# Altium schematic sheet ThermoFlagger.SchDoc(ThermoFlagger)
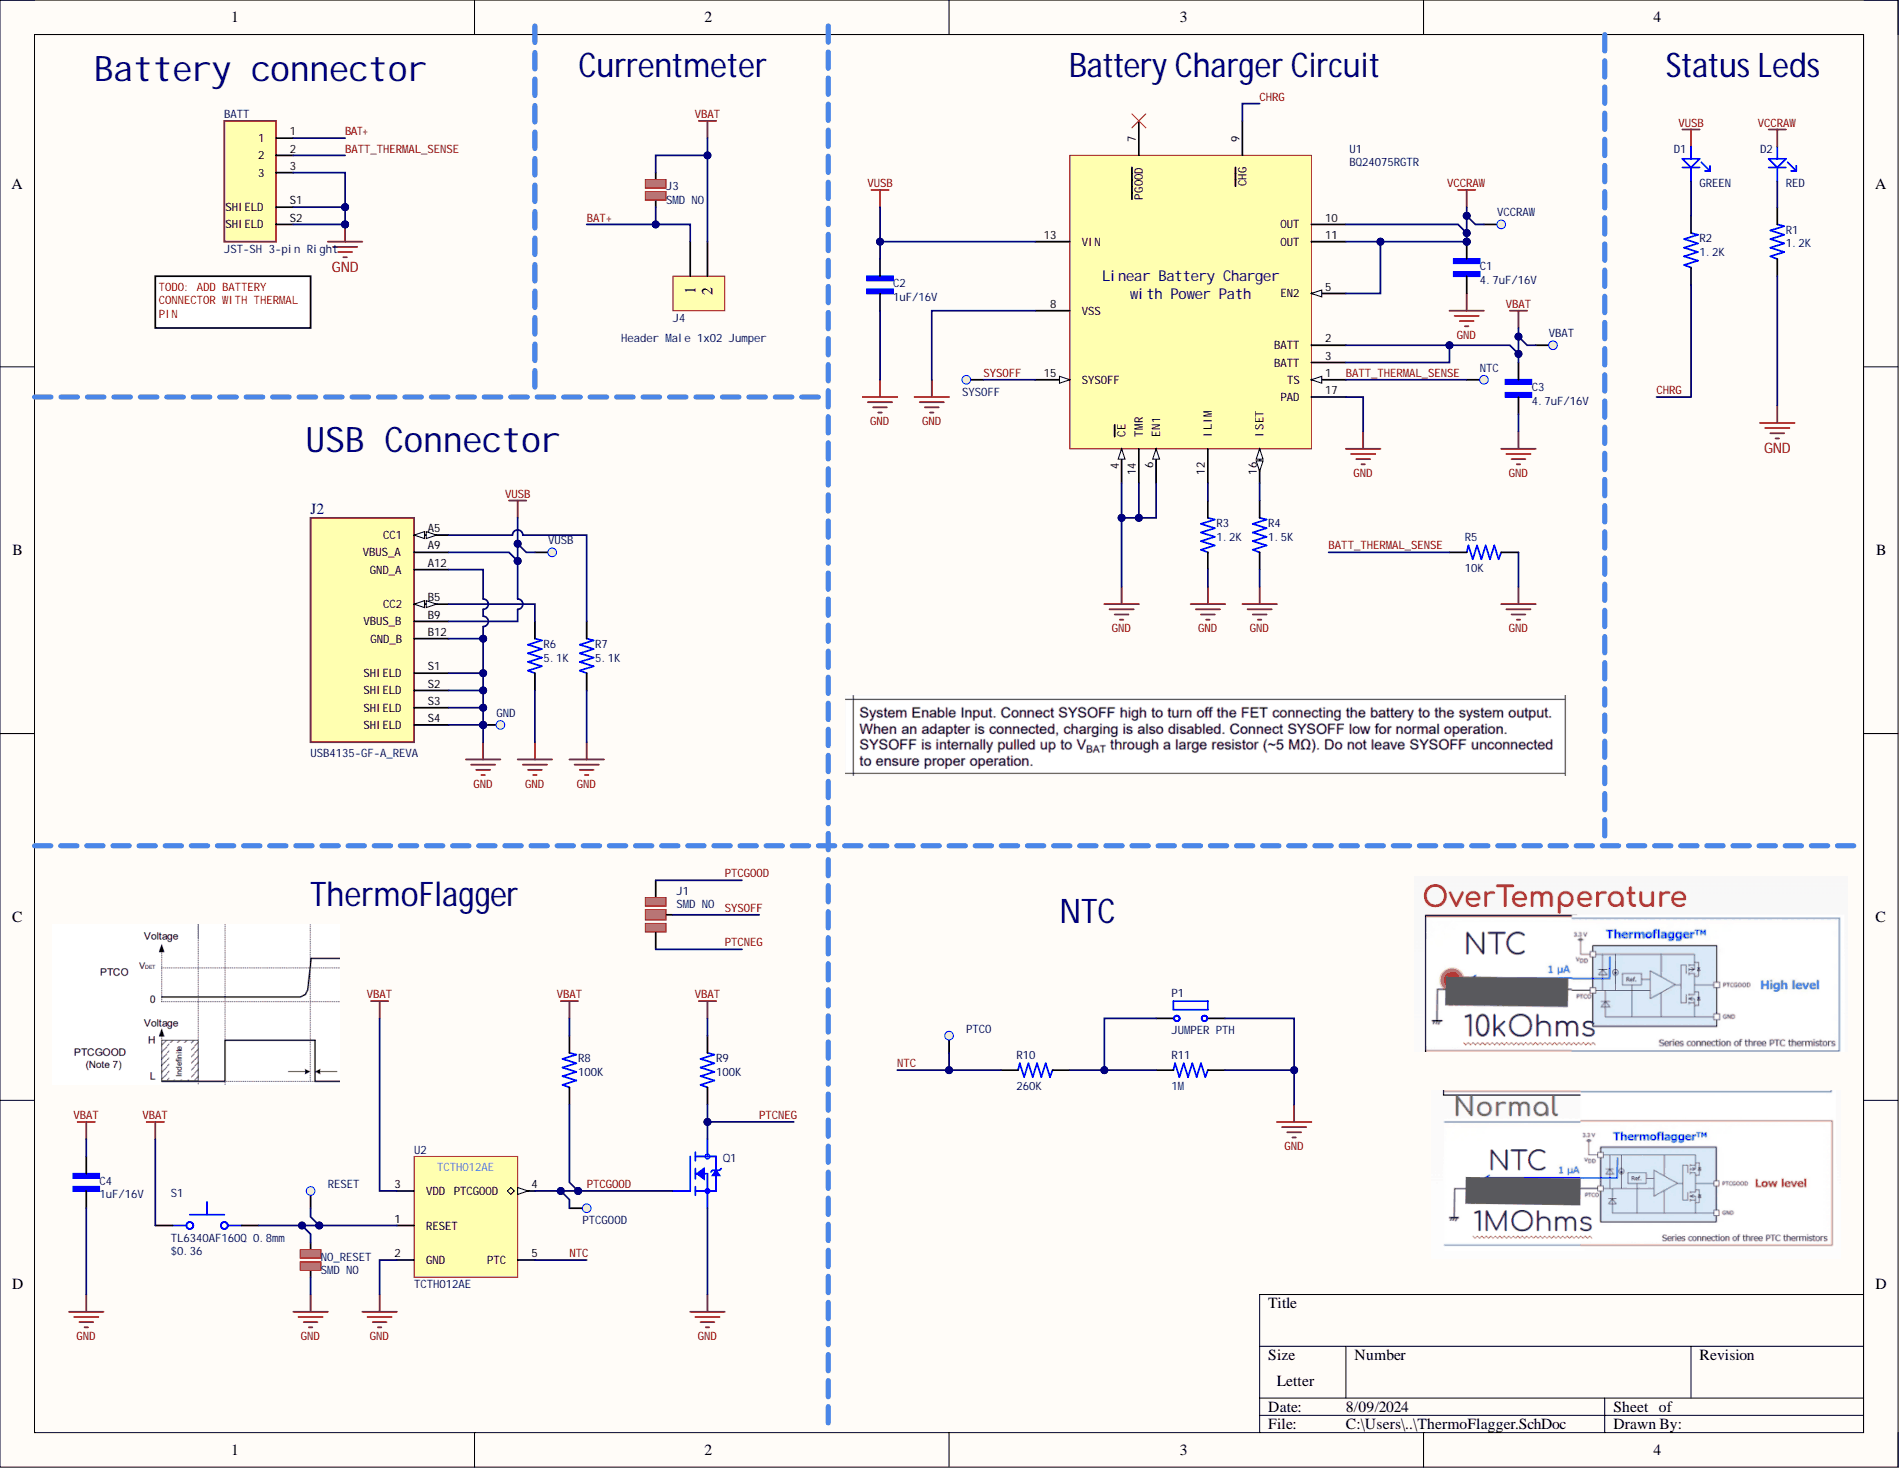
[diagram p0: ThermoFlagger.SchDoc]

## Parts
BATT  JST-SH 3-pin Right  [JST_BM03B-SRSS-RightAngle]
C1  4.7uF/16V  [C0603]
C2  1uF/16V  [C0603]
C3  4.7uF/16V  [C0603]
C4  1uF/16V  [C0603]
D1  GREEN  [LED 0603]
D2  RED  [LED 0603]
GND  Test point 1.2mm  [TEST_POINT_1.2MM]  (value not drawn)  (no BOM)
J1  SMD NO  [JUMPER_SMD_NO_2WAY]
J2  USB4135-GF-A_REVA  [GCT_USB4135 16-pin]
J3  SMD NO  [JUMPER_SMD_NO]
J4  Header Male 1x02 Jumper  [Header 1x02 Jumper]
NO_RESET  SMD NO  [JUMPER_SMD_NO]
NTC  Test point 1.2mm  [TEST_POINT_1.2MM]  (value not drawn)  (no BOM)
P1  JUMPER PTH  [HDR1X2]  (no BOM)
PTCGOOD  Test point 1.2mm  [TEST_POINT_1.2MM]  (value not drawn)  (no BOM)
PTCO  Test point 1.2mm  [TEST_POINT_1.2MM]  (value not drawn)  (no BOM)
Q1  0.19A/1.1V  [SOT-23-3]  (value not drawn)
R1  1.2K  [R0603]
R2  1.2K  [R0603]
R3  1.2K  [R0603]
R4  1.5K  [R0603]
R5  10K  [R0603]
R6  5.1K  [R0603]
R7  5.1K  [R0603]
R8  100K  [R0603]
R9  100K  [R0603]
R10  260K  [R0603]
R11  1M  [R0603]
RESET  Test point 1.2mm  [TEST_POINT_1.2MM]  (value not drawn)  (no BOM)
S1  TL6340AF160Q 0.8mm  Parameter 1: $0.36  [TL6340AF160Q]
SYSOFF  Test point 1.2mm  [TEST_POINT_1.2MM]  (value not drawn)  (no BOM)
U1  BQ24075RGTR  [VQFN16]
U2  TCTH012AE  [SOT-553 (ESV)]
VBAT  Test point 1.2mm  [TEST_POINT_1.2MM]  (value not drawn)  (no BOM)
VCCRAW  Test point 1.2mm  [TEST_POINT_1.2MM]  (value not drawn)  (no BOM)
VUSB  Test point 1.2mm  [TEST_POINT_1.2MM]  (value not drawn)  (no BOM)

## Nets
GND: BATT.3 BATT.S1(SHIELD) BATT.S2(SHIELD) C1.2 C2.2 C3.2 C4.2 GND.1 J2.A12(GND_A) J2.B12(GND_B) J2.S1(SHIELD) J2.S2(SHIELD) J2.S3(SHIELD) J2.S4(SHIELD) NO_RESET.1 P1.2 Q1.2 R1.1 R3.1 R4.1 R5.1 R6.1 R7.1 R11.1 U1.4(CE) U1.6(EN1) U1.8(VSS) U1.14(TMR) U1.17(PAD) U2.2(GND)
VBAT: C3.1 C4.1 J3.1 J4.2 R8.2 R9.2 S1.1 U1.2(BATT) U1.3(BATT) U2.3(VDD) VBAT.1
VCCRAW: C1.1 D2.1 U1.5(EN2) U1.10(OUT) U1.11(OUT) VCCRAW.1
VUSB: C2.1 D1.1 J2.A9(VBUS_A) J2.B9(VBUS_B) U1.13(VIN) VUSB.1
BAT+: BATT.1 J3.2 J4.1
BATT_THERMAL_SENSE: BATT.2 NTC.1 R5.2 U1.1(TS)
CHRG: R2.1 U1.9(CHG)
NTC: PTCO.1 R10.2 U2.5(PTC)
PTCGOOD: J1.3 PTCGOOD.1 Q1.1 R8.1 U2.4(PTCGOOD)
PTCNEG: J1.1 Q1.3 R9.1
SYSOFF: J1.2 SYSOFF.1 U1.15(SYSOFF)
NetD1_2: D1.2 R2.2
NetD2_2: D2.2 R1.2
NetJ2_A5: J2.A5(CC1) R7.2
NetJ2_B5: J2.B5(CC2) R6.2
NetNO_RESET_2: NO_RESET.2 RESET.1 S1.2 U2.1(RESET)
NetP1_1: P1.1 R10.1 R11.2
NetR3_2: R3.2 U1.12(ILIM)
NetR4_2: R4.2 U1.16(ISET)

Not connected: U1 7(PGOOD)

## Library data of the parts
BATT = JST-SH 3-pin Right: Connector Header, SR Series, 3 Position, 1.00mm, 50V; Manufacturer: JST; Manufacturer Part Number: BM03B-SRSS-TB(LF)(SN); Contact Resistance: 20mR; Current Rating: 1A; Gender: Male; Insulation Resistance: 100MR; Mated Stacking Heights: 4.9mm; Number of Contacts: 3; Category: Connectors; Color: Natural; Component Kind: Standard; Connector Type: Header; Contact Finish - Mating: TIN; Contact Material: Copper Alloy; Contact Plating: Tin,Lead; Contact Shape: Square; Contact Type: Male Pin; Fastening Type: Pull; Flammability Rating: UL94 V-0; Housing Color: Natural; Housing Material: Nylon; Insulation Color: Natural; Insulation Material: Nylon; Lead Free: Lead Free; Lead Pitch: 1mm; Library Name: Connectors.SchLib; Material: Polyamide; Max Operating Temperature: 85°C; Min Operating Temperature: -25°C; Mount: Surface Mount; Number of Positions: 3; Number of Rows: 1; Packaging: Cut Tape; Pricing 1: 5=0.1844, 50=0.1492, 150=0.1342, 1500=0.1078, 3000=0.0997, 4500=0.0948 (USD); Reach SVHC Compliant: No; RoHS: Yes; Series: SR; Stock 1: 136525; Supplier 1: LCSC; Supplier 2: Digi-Key; Supplier Part Number 1: C160389; Supplier Part Number 2: 455-1789-2-ND; Termination: Solder; Voltage Rating: 50V; Voltage Rating (AC): 50V
C1 C3 = C0603 4.7uF/16V: 0603 4.7 uF 16 V ±10% Tolerance X5R Multilayer Ceramic Capacitor; Manufacturer: Murata; Manufacturer Part Number: GRT188R61C475KE13D; Value: 4.7uF; Voltage Rating: 16V; Case Code (Imperial): 0603; Case Code (Metric): 1608; Case/Package: 0603; Category: Ceramic Capacitors; Component Kind: Standard; Length: 0.063inch; Library Name: Capacitors.SchLib; Max Operating Temperature: 85°C; Min Operating Temperature: -55°C; Mounting Technology: Surface Mount; Packaging: Cut Tape; Pricing 1: 1=0.16, 10=0.066, 50=0.066, 100=0.038, 1000=0.034, 10000=0.029 (USD); Ratings: AEC-Q200; RoHS: Yes; RoHS Compliant: Yes; Series: GRT; Stock 1: 39950; Supplier 1: Mouser; Supplier Part Number 1: 81-GRT188R61C475KE3D; Thickness: 0.035inch; Tolerance: 10%; Width: 0.031inch
C2 C4 = C0603 1uF/16V X5R: MLCC 1uF 16V X5R 10% SMD 0603 85C Paper T/R; Manufacturer: Yageo; Manufacturer Part Number: CC0603KRX5R7BB105; Dissipation Factor: 7%; Capacitance: 1uF; Case Code (Imperial): 0603; Case Code (Metric): 1608; Case/Package: 0603; Category: Ceramic Capacitors; Component Kind: Standard; Construction: Flat; Depth: 0.8mm; Dielectric: X5R; Halogen Free: Halogen Free; Height: 0.8mm; Lead Free: Lead Free; Length: 1.6mm; Library Name: Capacitors.SchLib; Max Operating Temperature: 85°C; Min Operating Temperature: -55°C; Mount: Surface Mount; Number of Pins: 2; Package Quantity: 4000; Packaging: Tape and Reel; Pricing 1: 1=0.17000, 10=0.09100, 50=0.09100, 100=0.04100, 1000=0.03400, 10000=0.02100 (USD); Radiation Hardening: No; REACH SVHC: Unknown; Resistor Type: Ceramic; RoHS: Yes; RoHS Compliant: Yes; Series: CC; Stock 1: 726921; Supplier 1: Mouser; Supplier Part Number 1: 603-CC603KRX5R7BB105; Termination: SMD/SMT; Thickness: 0.035inch; Tolerance: 10%; Voltage Rating: 16V; Voltage Rating (DC): 16V; Width: 0.8mm
D1 = LED 0603 GREEN: Green 575nm LED Indication - Discrete 2V 0603; Manufacturer: Wurth Electronics; Manufacturer Part Number: 150060VS55040; Dominant Wavelength: 573nm; Lens Size: 0.8mm; Lens Style: Flat; Lens Transparency: Diffused; Luminous Intensity: 60mcd; Test Current: 20mA; Viewing Angle: 140°; Case Code (Imperial): 0603; Case Code (Metric): 1608; Case/Package: 0603; Category: LEDs; Color: Green; Component Kind: Standard; Forward Voltage: 2V; Height: 0.5mm; Length: 1.6mm; Library Name: LED.SchLib; Mount: Surface Mount; Packaging: Cut Tape; Pricing 1: 1=0,18000, 10=0,16200, 50=0,16200, 100=0,14700, 1000=0,11700, 10000=0,11700 (USD); RoHS: No; Stock 1: 24940; Supplier 1: Mouser; Supplier Part Number 1: 710-150060VS55040; Width: 0.8mm
D2 = LED 0603 RED: Red 625nm LED Indication - Discrete 2V 0603; Manufacturer: Wurth Electronics; Manufacturer Part Number: 150060RS55040; Dominant Wavelength: 624nm; Lens Size: 0.8mm; Lens Style: Flat; Lens Transparency: Diffused; Luminous Intensity: 90mcd; Test Current: 20mA; Viewing Angle: 140°; Case Code (Imperial): 0603; Case Code (Metric): 1608; Case/Package: 0603; Category: LEDs; Color: Red; Component Kind: Standard; Forward Voltage: 2V; Height: 0.5mm; Length: 1.6mm; Library Name: LED.SchLib; Mount: Surface Mount; Packaging: Tape and Reel; Pricing 1: 1=0.18000, 10=0.16200, 100=0.14700, 500=0.13200, 1000=0.11700, 2000=0.11700 (USD); RoHS: No; Stock 1: 8586; Supplier 1: Mouser; Supplier Part Number 1: 710-150060RS55040; Width: 0.8mm
GND NTC PTCGOOD PTCO RESET SYSOFF VBAT VCCRAW VUSB = Test point: SMD test point; Library Name: Connectors.SchLib
J1 = JUMPER_SMD_NO_2WAY: Component Kind: Net Tie; Library Name: Jumpers.SchLib
J2 = USB4135-GF-A_REVA: Usb C Rec Gf Ra 6P SMT & SMT She; Manufacturer 1: Global Connector Technology; Category: Connectors; Component Kind: Standard; Library Name: Connectors.SchLib; Manufacturer Part Number 1: USB4135-GF-A; Pricing 1: 1=0.67300, 50=0.59700, 100=0.56000, 250=0.52200, 500=0.46600, 1000=0.43300, 1500=0.40000, 3000=0.33600 (USD); RoHS: No; Stock 1: 1196; Supplier 1: Mouser; Supplier Part Number 1: 640-USB4135-GF-A
J3 NO_RESET = JUMPER_SMD_NO: Rule: Short-Circuit Constraint [Short Circuit - Allowed]; Component Kind: Net Tie; Library Name: Jumpers.SchLib
J4 = Header Male Jumper 1x02: Component Kind: Standard; Description: Header Male Jumper 1x02; Library Name: Connectors.SchLib
P1 = JUMPER PTH NO: Description: JUMPER PTH NO; Library Name: Jumpers.SchLib
Q1 = MOSFET N-CH NX7002AK,215: MOSFET Transistor, N Channel, 190 mA, 60 V, 3 ohm, 10 V, 1.6 V; Manufacturer: Nexperia; Category: MOSFETs; Component Kind: Standard; Library Name: DiscreteSemi.SchLib; Manufacturer Part Number: NX7002AK,215; Pricing 1: 1=0.18, 10=0.131, 50=0.131, 100=0.059, 1000=0.036, 10000=0.021 (USD); RoHS: Yes; RoHS Compliant: Yes; Stock 1: 451760; Supplier 1: Mouser; Supplier 2: LCSC; Supplier Part Number 1: 771-NX7002AK,215; Supplier Part Number 2: C255584
R1-R3 = R0603 1.2K: RES 0603 1.2K OHM 1% 1/10W; Manufacturer: Yageo; Manufacturer Part Number: RC0603FR-071K2L; Max Power Dissipation: 100mW; Resistance: 1.2kR; Temperature Coefficient: 100ppm/°C; Voltage Rating: 50V; Case Code (Imperial): 0603; Case Code (Metric): 1608; Case/Package: 0603; Category: Chip SMD Resistors; Component Kind: Standard; ComponentLink1Description: http://www.farnell.com/datasheets/443971.pdf?src-supplier=Farnell; ComponentLink1URL: http://www.farnell.com/datasheets/443971.pdf?src-supplier=Farnell; ComponentLink2Description: http://www.farnell.com/datasheets/1868787.pdf?src-supplier=Farnell; ComponentLink2URL: http://www.farnell.com/datasheets/1868787.pdf?src-supplier=Farnell; ComponentLink3Description: http://www.farnell.com/datasheets/2167052.pdf?src-supplier=Farnell; ComponentLink3URL: http://www.farnell.com/datasheets/2167052.pdf?src-supplier=Farnell; ComponentLink4Description: http://www.mouser.com/ds/2/447/RC0603-272842.pdf?src-supplier=Mouser; ComponentLink4URL: http://www.mouser.com/ds/2/447/RC0603-272842.pdf?src-supplier=Mouser; ComponentLink5Description: http://components.arrow.com/part/dataview/0323_00047720?src-supplier=Arrow+Europe; ComponentLink5URL: http://components.arrow.com/part/dataview/0323_00047720?src-supplier=Arrow+Europe; ComponentLink6Description: http://www.farnell.com/datasheets/443971.pdf?src-supplier=Element14; ComponentLink6URL: http://www.farnell.com/datasheets/443971.pdf?src-supplier=Element14; ComponentLink7Description: http://www.farnell.com/datasheets/2167052.pdf?src-supplier=Newark; ComponentLink7URL: http://www.farnell.com/datasheets/2167052.pdf?src-supplier=Newark; ComponentLink8Description: http://www.farnell.com/datasheets/1868787.pdf?src-supplier=Element14; ComponentLink8URL: http://www.farnell.com/datasheets/1868787.pdf?src-supplier=Element14; ComponentLink9Description: http://www.farnell.com/datasheets/2167052.pdf?src-supplier=Element14; ComponentLink9URL: http://www.farnell.com/datasheets/2167052.pdf?src-supplier=Element14; ComponentLink10Description: http://www.yageo.com/documents/recent/PYu-RC0603_51_RoHS_L_7.pdf?src-supplier=Future+Electronics; ComponentLink10URL: http://www.yageo.com/documents/recent/PYu-RC0603_51_RoHS_L_7.pdf?src-supplier=Future+Electronics; ComponentLink11Description: https://www.verical.com/server-webapp/html/getDatasheet?partID=326670&src-supplier=Verical; ComponentLink11URL: https://www.verical.com/server-webapp/html/getDatasheet?partID=326670&src-supplier=Verical; ComponentLink12Description: http://www.farnell.com/datasheets/1868787.pdf?src-supplier=Newark; ComponentLink12URL: http://www.farnell.com/datasheets/1868787.pdf?src-supplier=Newark; ComponentLink13Description: http://www.yageo.com/documents/recent/PYu-RC_Group_51_RoHS_L_9.pdf?src-supplier=Digi-Key; ComponentLink13URL: http://www.yageo.com/documents/recent/PYu-RC_Group_51_RoHS_L_9.pdf?src-supplier=Digi-Key; ComponentLink14Description: http://www.yageo.com/documents/recent/PYu-RC_Group_51_RoHS_L_10.pdf?src-supplier=Digi-Key; ComponentLink14URL: http://www.yageo.com/documents/recent/PYu-RC_Group_51_RoHS_L_10.pdf?src-supplier=Digi-Key; Construction: Rectangular; Depth: 0.8mm; Features: Moisture Resistant; Height: 0.45mm; Lead Free: Lead Free; Length: 1.6mm; Library Name: Resistors.SchLib; Max Operating Temperature: 155°C; Military Standard: Not; Min Operating Temperature: -55°C; Number of Pins: 2; Number of Terminations: 2; Packaging: Tape and Reel; Power Rating: 100mW; Pricing 1: 1=0.10000, 10=0.02400, 50=0.02400, 100=0.01000, 1000=0.00400, 10000=0.00300 (USD); REACH SVHC: No SVHC; Resistance Tolerance: 1%; Resistor Type: Thick Film; RoHS: Yes; RoHS Compliant: Yes; Series: RC; Size Code: 0603; Stock 1: 27884; Supplier 1: Mouser; Supplier Part Number 1: 603-RC0603FR-071K2L; Termination: SMD/SMT; Tolerance: 1%; Voltage Rating (DC): 50V; Width: 0.8mm
R4 = R0603 1.5K: RES 0603 1.5K OHM 0.5% 1/10W; Manufacturer: Yageo; Manufacturer Part Number: RC0603FR-071K5L; Max Power Dissipation: 100mW; Resistance: 1.5kR; Temperature Coefficient: 100ppm/°C; Tolerance: 1%; Voltage Rating: 50V; Case Code (Imperial): 0603; Case Code (Metric): 1608; Case/Package: 0603; Category: Chip SMD Resistors; Component Kind: Standard; Depth: 0.8mm; Features: Moisture Resistant; Height: 0.022inch; Lead Free: Lead Free; Length: 0.063inch; Library Name: Resistors.SchLib; Max Operating Temperature: 155°C; Military Standard: Not; Min Operating Temperature: -55°C; Number of Pins: 2; Number of Terminations: 2; Package Quantity: 1; Packaging: Tape and Reel; Power Rating: 100mW; Pricing 1: 1=0.10000, 10=0.01800, 50=0.01800, 100=0.00600, 1000=0.00500, 10000=0.00300 (USD); REACH SVHC: No SVHC; Resistor Type: Thick Film; RoHS: Yes; Stock 1: 312486; Supplier 1: Mouser; Supplier Part Number 1: 603-RC0603FR-071K5L; Termination: SMD/SMT; Voltage Rating (DC): 50V; Width: 0.031inch
R5 = R0603 10K: SMD Chip Resistor, 10 kOhm, ± 1%, 100 mW, 0603 [1608 Metric], Thick Film, Anti-Sulfur; Manufacturer 1: Yageo; Manufacturer Part Number 1: AF0603FR-0710KL; Max Power Dissipation: 100mW; Temperature Coefficient: 100ppm/°C; Value: 10kR; Voltage Rating: 50V; Case Code (Imperial): 0603; Case Code (Metric): 1608; Case/Package: 0603; Category: Chip SMD Resistors; Component Kind: Standard; Features: Moisture Resistant,Anti-Sulfur; Height: 0.022inch; Lead Free: 5697LeadFree; Length: 0.063inch; Library Name: Resistors.SchLib; Max Operating Temperature: 155°C; Min Operating Temperature: -55°C; Number of Terminations: 2; Package Quantity: 1; Packaging: Tape and Reel; Power Rating: 100mW; Pricing 3: 5000=0.00223, 10000=0.00219, 20000=0.00213 (USD); RoHS: Yes; RoHS Compliant: Yes; Series: AF; Stock 3: 5000; Supplier 1: Mouser; Supplier 2: Mouser; Supplier Part Number 1: 603-AF0603FR-0710KL; Supplier Part Number 2: 667-ERJ-3EKF1002V; Termination: SMD/SMT; Tolerance: 1%; Width: 0.031inch
R6 R7 = R0603 5.1K: RES 0603 5.1K OHM 1% 1/10W; Manufacturer: Yageo; Manufacturer Part Number: RC0603FR-075K1L; Max Power Dissipation: 100mW; Resistance: 5.1kR; Temperature Coefficient: 100ppm/°C; Voltage Rating: 50V; Case Code (Imperial): 0603; Case Code (Metric): 1608; Case/Package: 0603; Category: Chip SMD Resistors; Component Kind: Standard; Depth: 0.8mm; Features: Moisture Resistant; Height: 0.022inch; Lead Free: Lead Free; Length: 0.063inch; Library Name: Resistors.SchLib; Max Operating Temperature: 155°C; Military Standard: Not; Min Operating Temperature: -55°C; Number of Pins: 2; Number of Terminations: 2; Package Quantity: 1; Packaging: Tape and Reel; Power Rating: 100mW; REACH SVHC: No SVHC; Resistor Type: Thick Film; RoHS: Yes; Stock 1: 557916; Supplier 1: Mouser; Supplier Part Number 1: 603-RC0603FR-075K1L; Termination: SMD/SMT; Tolerance: 1%; Voltage Rating (DC): 50V; Width: 0.031inch
R8 R9 = R0603 100K: RES 0603 100K OHM 1% 1/10W; Manufacturer 1: Yageo; Manufacturer Part Number 1: RC0603FR-07100KL; Power Rating: 100mW; Resistance: 100kR; Temperature Coefficient: 100ppm/°C; Voltage Rating: 50V; Working Voltage: 75V; Case Code (Imperial): 0603; Case Code (Metric): 1608; Case/Package: 0603; Category: Chip SMD Resistors; Component Kind: Standard; Depth: 0.8mm; Height: 0.45mm; Lead Free: Lead Free; Length: 1.6mm; Library Name: Resistors.SchLib; Max Operating Temperature: 155°C; Military Standard: Not; Min Operating Temperature: -55°C; Number of Pins: 2; Number of Terminations: 2; Packaging: Tape and Reel; Pricing 1: 1=0.10000, 10=0.02100, 100=0.00900, 1000=0.00400, 5000=0.00300, 10000=0.00200 (USD); REACH SVHC: No SVHC; Resistor Type: Thick Film; RoHS: Yes; Stock 1: 4536807; Supplier 1: Mouser; Supplier Part Number 1: 603-RC0603FR-07100KL; Termination: SMD/SMT; Tolerance: 1%; Voltage Rating (DC): 50V; Width: 0.8mm
R10 = R0603 215K: RES 0603 215K OHM 1% 1/10W; Manufacturer 1: Yageo; Manufacturer Part Number 1: RC0603FR-07215KL; Max Power Dissipation: 100mW; Resistance: 215kR; Temperature Coefficient: 100ppm/°C; Voltage Rating: 75V; Voltage Rating (DC): 50V; Case Code (Imperial): 0603; Case Code (Metric): 1608; Case/Package: 0603; Category: Chip SMD Resistors; Component Kind: Standard; Construction: Rectangular; Depth: 0.8mm; Features: Moisture Resistant; Height: 0.022inch; Lead Free: Lead Free; Length: 1.6mm; Library Name: Resistors.SchLib; Max Operating Temperature: 155°C; Military Standard: Not; Min Operating Temperature: -55°C; Number of Terminations: 2; Package Quantity: 1; Packaging: Tape and Reel; Power Rating: 100mW; Pricing 1: 1=0,10000, 10=0,01800, 50=0,01800, 100=0,00700, 1000=0,00400, 10000=0,00200 (USD); Resistor Type: Thick Film; RoHS: Yes; RoHS Compliant: Yes; Series: RC; Size Code: 0603; Stock 1: 61268; Supplier 1: Mouser; Supplier 2: Mouser; Supplier Part Number 1: 603-RC0603FR-07215KL; Supplier Part Number 2: 603-AC0603FR-07215KL; Termination: SMD/SMT; Tolerance: 1%; Weight: 7.1E-05oz; Width: 0.031inch
R11 = R0603 1M: RES 0603 1M OHM 1% 1/10W; Manufacturer 1: Yageo; Manufacturer Part Number 1: RC0603FR-071ML; Application(s): HIGH RELIABILITY; Power Rating: 100mW; Resistance: 1MR; Temperature Coefficient: 100ppm/°C; Voltage Rating: 75V; Voltage Rating (DC): 50V; Case Code (Imperial): 0603; Case Code (Metric): 1608; Case/Package: 0603; Category: Chip SMD Resistors; Component Kind: Standard; Depth: 0.8mm; Height: 0.45mm; Lead Free: Lead Free; Length: 1.6mm; Library Name: Resistors.SchLib; Max Operating Temperature: 155°C; Military Standard: Not; Min Operating Temperature: -55°C; Number of Pins: 2; Package Quantity: 5000; Packaging: Cut Tape; Pricing 1: 1=0.10000, 10=0.01800, 50=0.01800, 100=0.00700, 1000=0.00400, 10000=0.00200 (USD); REACH SVHC: No SVHC; Resistor Type: Thick Film; RoHS: Yes; RoHS Compliant: Yes; Series: RC; Stock 1: 1321822; Supplier 1: Mouser; Supplier Part Number 1: 603-RC0603FR-071ML; Technology: THICK FILM; Termination: SMD/SMT; Tolerance: 1%; Type: General Purpose; Weight: 0.000847oz; Width: 0.8mm
S1 = TL6340AF160Q 0.8mm: TACTILE SWITCH, SPST, 0.02A/12VDC, SMD; Product Range: TL6340 Series; Actuator Orientation: Side Actuated; Switch...; Manufacturer: E-Switch; Category: Tactile Switches; Component Kind: Standard; Library Name: Switches.SchLib; Manufacturer Part Number: TL6340AF160Q; Pricing 1: 1=0.51, 10=0.478, 50=0.478, 100=0.46, 1000=0.36, 10000=0.255 (USD); RoHS: Yes; Stock 1: 2636; Supplier 1: Mouser; Supplier 2: LCSC; Supplier Part Number 1: 612-TL6340AF160QG; Supplier Part Number 2: C4944967
U1 = BQ24075RGTR: IC LI-ION CHARGE MGMT 16-QFN; Manufacturer: Texas Instruments; Battery Chemistry: LITHIUM-ION; Battery Pack Voltage: 4.2V; Fault Protection: Over Voltage,Over Temperature,Short Circuit,Reverse Current; Interface: USB; Max Charge Current: 1.5A; Max Supply Voltage: 6.4V; Min Input Voltage: 4.3V; Min Supply Voltage: 4.35V; Number of Cells: 1; Case/Package: VQFN; Category: Battery Management; Component Kind: Standard; ComponentLink1Description: https://datasheet.ciiva.com/15114/getdatasheetpartid-471953-15114762.pdf?src-supplier=Verical; ComponentLink1URL: https://datasheet.ciiva.com/15114/getdatasheetpartid-471953-15114762.pdf?src-supplier=Verical; ComponentLink2Description: https://datasheet.ciiva.com/3214/bq24079-3214043.pdf?src-supplier=Avnet; ComponentLink2URL: https://datasheet.ciiva.com/3214/bq24079-3214043.pdf?src-supplier=Avnet; ComponentLink3Description: https://datasheet.ciiva.com/1934/bq24072-1934514.pdf?src-supplier=Digi-Key; ComponentLink3URL: https://datasheet.ciiva.com/1934/bq24072-1934514.pdf?src-supplier=Digi-Key; Contact Plating: Gold; Lead Free: Lead Free; Library Name: IC Power.SchLib; Manufacturer Part Number: BQ24075RGTR; Max Operating Temperature: 125°C; Min Operating Temperature: -40°C; Number of Pins: 16; Output Current: 1.5A; Output Voltage: 4.2V; Package Quantity: 3000; Packaging: Tape and Reel; Pricing 1: 1=2.52000, 10=2.27000, 50=2.14000, 100=1.82000, 1000=1.24000, 10000=1.24000 (USD); Radiation Hardening: No; RoHS: Yes; RoHS Compliant: Yes; Series: -; Stock 1: 21933; Supplier 1: Mouser; Supplier 2: Digi-Key; Supplier 3: Digi-Key; Supplier 4: Arrow Electronics; Supplier Part Number 1: 595-BQ24075RGTR; Supplier Part Number 2: 296-38874-1-ND; Supplier Part Number 3: 296-38874-2-ND; Supplier Part Number 4: BQ24075RGTR; Weight: 0.000808oz
U2 = TCTH012AE: Supervisory Circuits CMOS Linear IC Over Temp Detection IC IPTCO: 1uA Latching-func PTCGOOD: Push-Pull; Manufacturer 1: Toshiba; Manufacturer Part Number 1: TCTH012AE,LF(CT; Component Kind: Standard; Library Name: IC Logic.SchLib; Pricing 1: 1=0.38, 10=0.296, 50=0.296, 100=0.265, 1000=0.201, 10000=0.154 (USD); RoHS: No; Stock 1: 8000; Supplier 1: Mouser; Supplier Part Number 1: 757-TCTH012AELFCT
